# Altium schematic sheet 07-TCXO_Crystal.SchDoc(07-TCXO_Crystal)
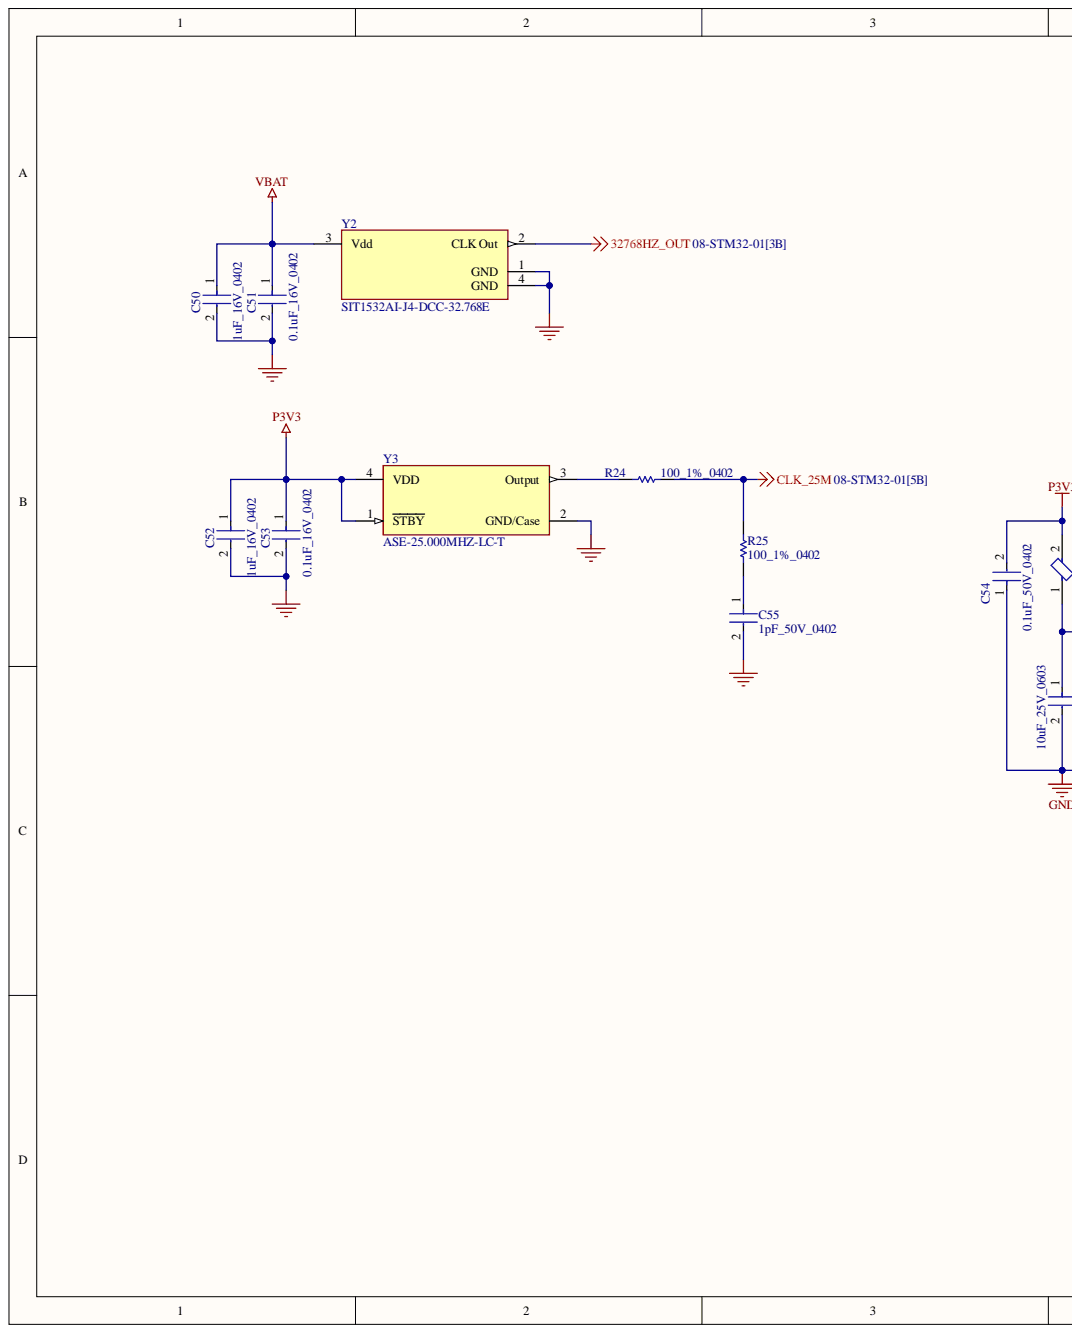
[diagram p0: 07-TCXO_Crystal.SchDoc, left]
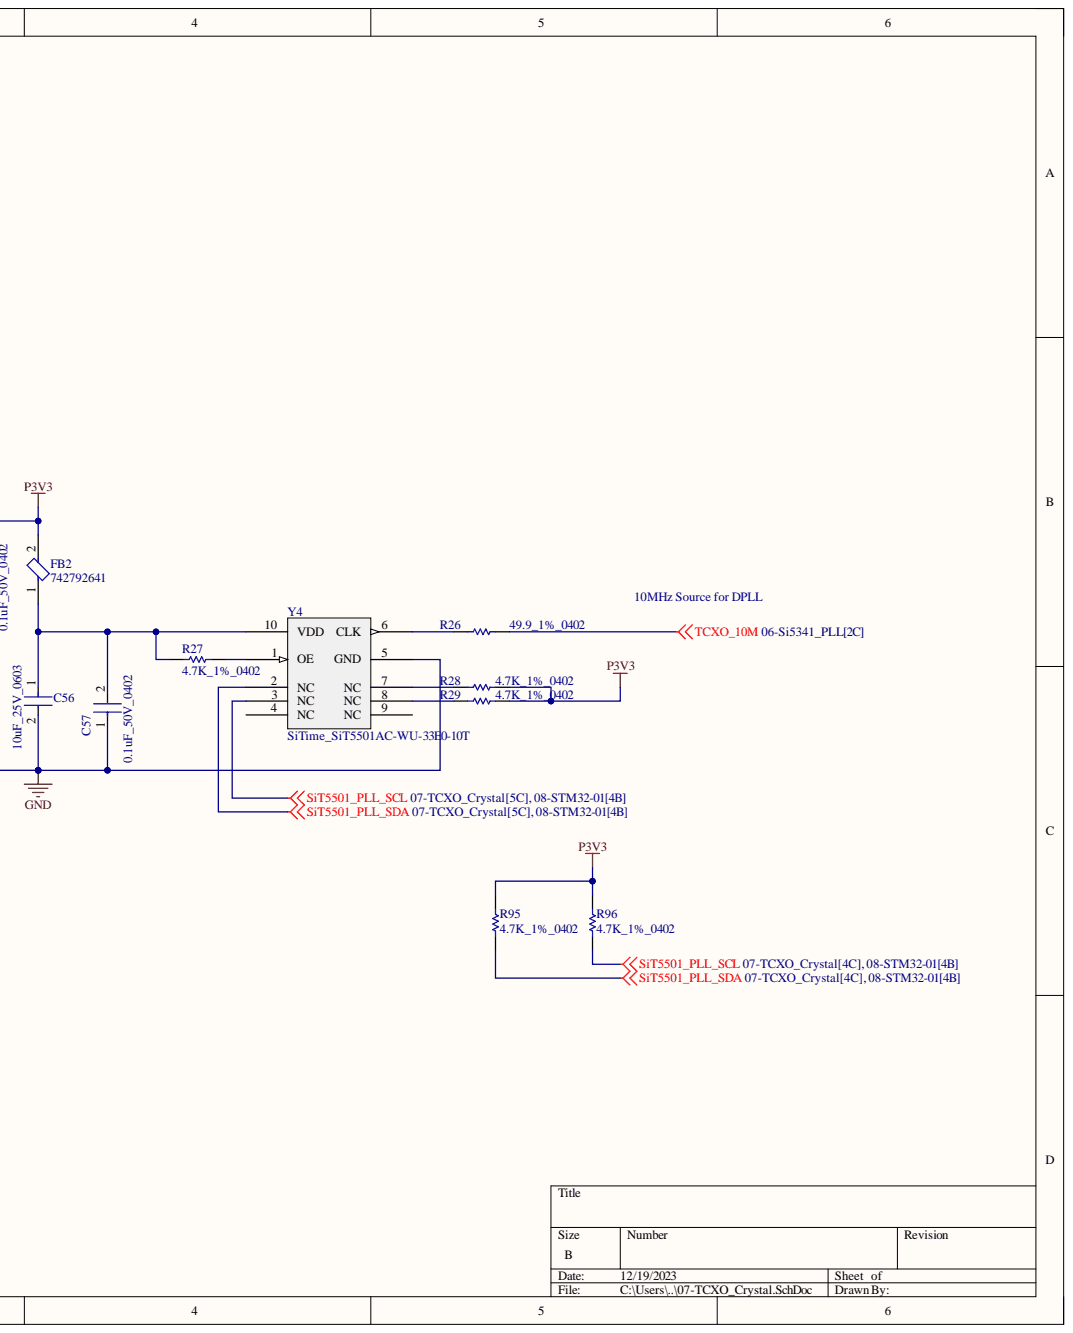
[diagram p1: 07-TCXO_Crystal.SchDoc, right]

## Parts
C50  1uF_16V_0402  [FP-0402-L_1_0_0_05-W_0_5-IPC_A]
C51  0.1uF_16V_0402  [FP-C0402-BB-MFG]
C52  1uF_16V_0402  [FP-0402-L_1_0_0_05-W_0_5-IPC_A]
C53  0.1uF_16V_0402  [FP-C0402-BB-MFG]
C54  0.1uF_50V_0402  [FP-C1005-050-0_05-IPC_C]
C55  1pF_50V_0402  [FP-GJM155-0_05-IPC_B]
C56  10uF_25V_0603  [FP-CL10-IPC_C]
C57  0.1uF_50V_0402  [FP-C1005-050-0_05-IPC_C]
FB2  742792641  [FP-742792641-MFG]
R24  100_1%_0402  [RESC1005X40X25NL05T10]
R25  100_1%_0402  [RESC1005X40X25NL05T10]
R26  49.9_1%_0402  [RESC1005X40X25NL10T10]
R27  4.7K_1%_0402  [RESC1005X40X25NL10T10]
R28  4.7K_1%_0402  [RESC1005X40X25NL10T10]
R29  4.7K_1%_0402  [RESC1005X40X25NL10T10]
R95  4.7K_1%_0402  [RESC1005X40X25NL10T10]
R96  4.7K_1%_0402  [RESC1005X40X25NL10T10]
Y2  SIT1532AI-J4-DCC-32.768E  [FP-SIT1532-MFG]
Y3  ASE-25.000MHZ-LC-T  [FP-ASE-MFG]
Y4  SiTime_SiT5501AC-WU-33E0-10T  [SITIME_SPL-086-CQFN-010-C07050_REV_DRAFT]

## Nets
32768HZ_OUT: Y2.2(CLK_Out)
CLK_25M: R24.2 R25.2
GND: C50.2 C51.2 C52.2 C53.2 C54.1 C55.2 C56.2 C57.1 Y2.1(GND) Y2.4(GND) Y3.2(GND/Case) Y4.5(GND)
P3V3: C52.1 C53.1 C54.2 FB2.2 R28.2 R29.2 R95.2 R96.2 Y3.1(STBY) Y3.4(VDD)
SiT5501_PLL_SCL: R96.1 Y4.3(NC)
SiT5501_PLL_SDA: R95.1 Y4.2(NC)
TCXO_10M: R26.1
VBAT: C50.1 C51.1 Y2.3(Vdd)
NetC55_1: C55.1 R25.1
NetC56_1: C56.1 C57.2 FB2.1 R27.1 Y4.10(VDD)
NetR24_1: R24.1 Y3.3(Output)
NetR26_2: R26.2 Y4.6(CLK)
NetR27_2: R27.2 Y4.1(OE)
NetR28_1: R28.1 Y4.7(NC)
NetR29_1: R29.1 Y4.8(NC)

Not connected: Y4 4(NC) 9(NC)

## Library data of the parts
C50 C52 = CMP-14477-000104-2: None; Capacitance: 1uF; Dielectric: X5R; Tolerance: 10%; Voltage Rating: 16V; Case Code (Imperial): 0402; Case Code (Metric): 1005; Case/Package: 0402; Component Kind: Standard; Construction: Flat; Depth: 0.5mm; Halogen Free: Halogen Free; Height: 0.5mm; Length: 1mm; Library Name: Altium Content Vault; Max Operating Temperature: 85°C; Min Operating Temperature: -55°C; Mount: Surface Mount; Number of Pins: 2; Package Quantity: 1; Packaging: Tape and Reel; Radiation Hardening: No; RoHS Compliant: Yes; Series: M; Termination: SMD/SMT; Thickness: 0.022inch; Voltage Rating (DC): 16V; Width: 0.02inch
C51 C53 = CMP-1034-02068-3: CAP CER 0.1UF 16V 5% X7R 0402; Manufacturer: Kemet; Manufacturer Part Number: C0402C104J4RACTU; Capacitance: 0.10 μF; Material: Ceramic; Temperature Coefficient: 15%; Tolerance: 0.05; Value: 0.10 μF; Automotive: No; Category: Cap; Component Kind: Standard; ComponentLink2Description: Datasheet; ComponentLink2URL: https://content.kemet.com/datasheets/KEM_C1002_X7R_SMD.pdf; Device Class L1: Passive Components; Device Class L2: Capacitors; Device Class L3: Ceramic Capacitors; Digikey Description: CAP CER 0.1UF 16V 5% X7R 0402; Height: 0.55mm; Ipc Land Pattern Name: CAPC10050X50; Lead Free: Yes; Library Name: Altium Content Vault; Mouser Description: CAP CER 0.1UF 16V X7R 0402; Octopart: https://octopart.com/c0402c104j4ractu-kemet-12573893; Package: C0402-BB; RoHS: TRUE; Temperature: -55°C to +125°C; Temperature Characteristic: X7R; Temperature Range High: +125°C; Temperature Range Low: -55°C; Voltage Rating: 16 V
C54 C57 = CMP-2000-05393-2: Multilayer Ceramic Capacitors 100nF ±10% 50V X7R SMD 0402; Capacitance: 100nF; Case Code (Imperial): 0402; Case Code (Metric): 1005; Case/Package: 0402; Component Kind: Standard; Construction: Flat; Depth: 0.5mm; Dielectric: X7R; Dielectric Material: Ceramic; Height: 0.5mm; Lead Free: Lead Free; Length: 1mm; Library Name: Altium Content Vault; Max Operating Temperature: 125°C; Min Operating Temperature: -55°C; Mount: Surface Mount; Package Quantity: 1; Packaging: Tape and Reel; Radiation Hardening: No; REACH SVHC: No SVHC; Resistor Type: Ceramic; RoHS Compliant: Yes; Series: C; Termination: SMD/SMT; Thickness: 0.022inch; Tolerance: 10%; Voltage: 50V; Voltage Rating: 50V; Voltage Rating (DC): 50V; Weight: 2.3E-05oz; Width: 0.02inch
C55 = CMP-2008-03808-2: Multi-Layer Ceramic Capacitor 1pF C0G  ±0.1pF 0402 Paper T/R; Temperature Coefficient: 30ppm/°C; Voltage: 50V; Capacitance: 1pF; Case Code (Imperial): 0402; Case Code (Metric): 1005; Case/Package: 0402; Component Kind: Standard; Depth: 0.5mm; Dielectric: C0G; Height: 0.5mm; Lead Free: Lead Free; Lead Pitch: 0.3mm; Length: 1mm; Library Name: Altium Content Vault; Max Operating Temperature: 125°C; Min Operating Temperature: -55°C; Mount: Surface Mount; Number of Pins: 2; Package Quantity: 10000; Packaging: Tape and Reel; Radiation Hardening: No; REACH SVHC: No SVHC; Termination: SMD/SMT; Thickness: 0.022inch; Voltage Rating: 50V; Voltage Rating (DC): 50V; Width: 0.02inch
C56 = CMP-13271-004224-1: Cap Ceramic 10uF 25V X5R ±20% Pad SMD 0603 +85°C T/R; Capacitance: 10uF; Case Code (Imperial): 0603; Case Code (Metric): 1608; Case/Package: 0603; Component Kind: Standard; Contact Plating: Tin; Dielectric: X5R; Lead Free: Lead Free; Length: 0.063inch; Library Name: Altium Content Vault; Max Operating Temperature: 85°C; Min Operating Temperature: -55°C; Mount: Surface Mount; Package Quantity: 1; Packaging: Tape and Reel; Termination: Wraparound; Thickness: 0.035inch; Tolerance: 20%; Voltage Rating: 25V; Width: 0.031inch
FB2 = CMP-0220-00171-2: FERRITE BEAD 300 OHM 0603 1LN; Current Rating: 2A; DC Resistance (DCR): 150mR; Impedance: 300R; Inductance: 18nH; Number of Lines: 1; Test Frequency: 100MHz; Tolerance: 25%; Case Code (Imperial): 0603; Case Code (Metric): 1608; Case/Package: SMD/SMT; Component Kind: Standard; Core Material: Ferrite; Depth: 0.8mm; Height: 0.8mm; Lead Free: Lead Free; Length: 1.6mm; Library Name: Altium Content Vault; Material: Ferrite; Max Current Rating: 2A; Max DC Current: 2A; Max Operating Temperature: 125°C; Min Operating Temperature: -55°C; Mount: Surface Mount; Package Quantity: 1; Packaging: Tape and Reel; RoHS Compliant: Yes; Series: WE-CBF; Termination: SMD/SMT; Weight: 0.000141oz; Width: 0.8mm
R24 R25 = CMP-2002-07853-1: Manufacturer: Yageo; Part Number: RC0402FR-13100RL; Component Kind: Standard; Library Name: Altium Content Vault
R26 = CMP-2002-04448-1: Manufacturer: KOA Speer; Part Number: RK73H1ETTP49R9F; Component Kind: Standard; Library Name: Altium Content Vault
R27-R29 R95 R96 = CMP-2002-05217-1: Manufacturer: Bourns; Part Number: CR0402-FX-4701GLF; Component Kind: Standard; Library Name: Altium Content Vault
Y2 = CMP-25159-261190-1: MEMS OSC XO 32.7680KHZ LVCMOS; Current Rating: 1.35uA; Frequency: 32.768kHz; Frequency Stability: 100ppm; Load Capacitance: 10pF; Max Duty Cycle: 52%; Max Supply Current: 1.4uA; Max Supply Voltage: 3.63V; Min Supply Voltage: 1.5V; Component Kind: Standard; Height: 0.6mm; Lead Free: Lead Free; Length: 1.5mm; Library Name: Altium Content Vault; Max Operating Temperature: 85°C; Min Operating Temperature: -40°C; Mount: Surface Mount; Package Quantity: 1000; Packaging: Tape and Reel; RoHS Compliant: Yes; Series: SiT1532; Termination: SMD/SMT; Width: 0.8mm
Y3 = CMP-2000-05795-3: XTAL Oscillator 25MHz ±50ppm CMOS 3.3V 4-SMD 3.2mm x 2.5mm; Load Capacitance: 15pF; Max Supply Current: 13mA; Max Supply Voltage: 3.465V; Min Supply Voltage: 3.135V; Case/Package: SMD/SMT; Component Kind: Standard; Depth: 2.5mm; Frequency: 25MHz; Frequency Stability: 50ppm; Height: 0.047inch; Lead Free: Lead Free; Length: 3.2mm; Library Name: Altium Content Vault; Max Operating Temperature: 85°C; Min Operating Temperature: -40°C; Mount: Surface Mount; Number of Pins: 4; Operating Frequency: 25 MHz; Operating Supply Voltage: 3.3V; Package Quantity: 1000; Packaging: Tape and Reel; Radiation Hardening: No; Series: ASE; Termination: SMD/SMT; Width: 2.5mm
Y4 = CMP-OSC-00029-2: OSC, MEMS, STRATUM 3E, 10.000MHZ, 10PPB STAB, LVCMOS, 3.3V, O/P EN, 7.0X5.0X2.2MM, CQFN-10; Manufacturer: SiTime; Manufacturer Part Number: SiT5501AI-WU033JH-10.000000; Frequency: 10 MHz; Case/Package: 10 Lead QFN; Category: Oscillators; Component Kind: Standard; Frequency Stability: ±10 ppb; Height: 2.20mm (max); Max Operating Temperature: 70°C; Min Operating Temperature: -20°C; Mounting Type: Surface Mount; Output: LVCMOS; RoHS: Yes; Type: OCXO; Voltage - Supply: 3.3 V; Library Name: OCXO_DaughterCard.SCHLIB
